# Altium schematic sheet Fluorimetro_Sheet.SchDoc(Fluorimetro_Sheet)
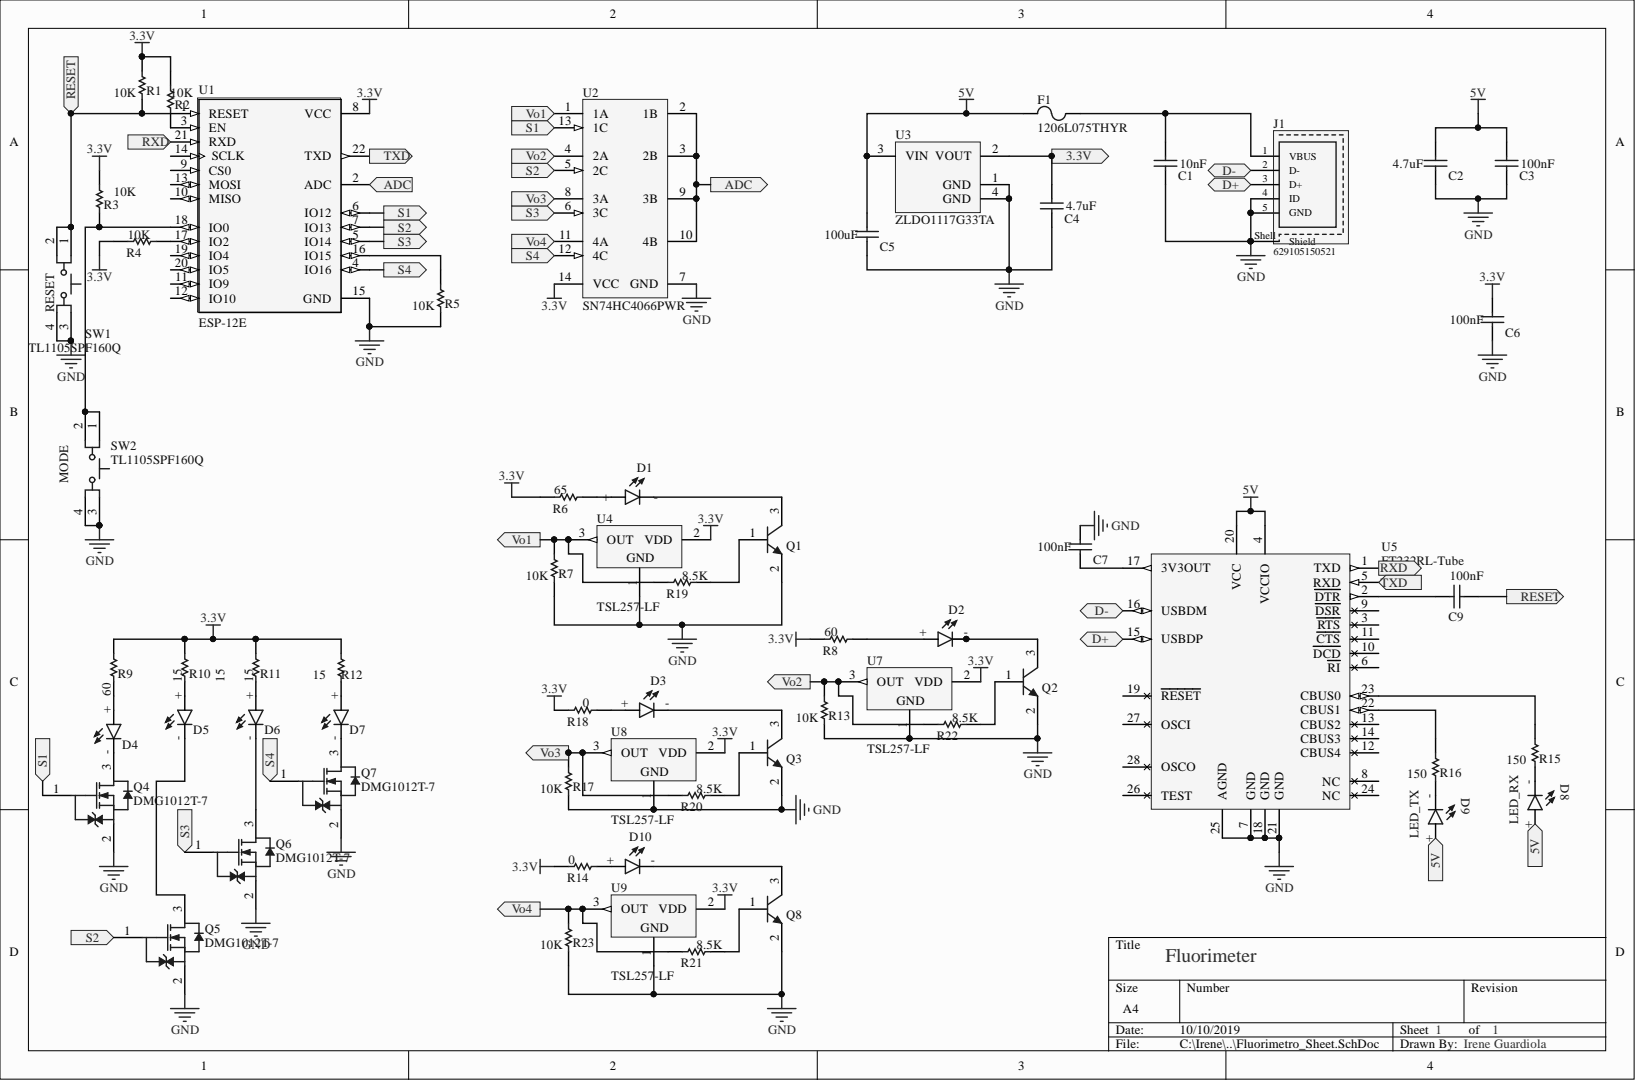
[diagram p0: Fluorimetro_Sheet.SchDoc]

## Parts
C1  CAP 0805  [CAP0805]  (value not drawn)
C2  CAP 0805  [CAP0805]  (value not drawn)
C3  GRM155R60J105KE19D  [CAP0603]  (value not drawn)
C4  CAP 0805  [CAP0805]  (value not drawn)
C5  GRM155R60J105KE19D  [CAP0603]  (value not drawn)
C6  GRM155R60J105KE19D  [CAP0603]  (value not drawn)
C7  GRM155R60J105KE19D  [CAP0603]  (value not drawn)
C9  GRM155R60J105KE19D  [CAP0603]  (value not drawn)
D1  LTST-C171KSKT  [DIOC200X125X110_LTST-C171KSKT(Primary) - duplicate - duplicate]  (value not drawn)
D2  LTST-C171GKT  [LTST-C171GKT]  (value not drawn)
D3  APT2012VBC/D  [19-21/BHC-AN1P2/3T]  (value not drawn)
D4  LTL-4273  [LED]  (value not drawn)
D5  LTL2R3TGY3KS  [LED]  (value not drawn)
D6  LTL2R3TBV3KS  [LED]  (value not drawn)
D7  VAOL-5GUV0T4  [LED]  (value not drawn)
D8  LTST-C171KRKT  [DIOC200X125X110_LTST-C171KRKT]  (value not drawn)
D9  LTST-C171KRKT  [DIOC200X125X110_LTST-C171KRKT]  (value not drawn)
D10  LTW-150TK  [LTW-150TK]  (value not drawn)
F1  1206L075THYR  [1206L075THYR]
J1  629105150521  [629105150521]
Q1  2SC5646A-TL-H  [2SC5646A-TL-H]  (value not drawn)
Q2  2SC5646A-TL-H  [2SC5646A-TL-H]  (value not drawn)
Q3  2SC5646A-TL-H  [2SC5646A-TL-H]  (value not drawn)
Q4  DMG1012T-7  [DIOD-SOT523-3_V]
Q5  DMG1012T-7  [DIOD-SOT523-3_V]
Q6  DMG1012T-7  [DIOD-SOT523-3_V]
Q7  DMG1012T-7  [DIOD-SOT523-3_V]
Q8  2SC5646A-TL-H  [2SC5646A-TL-H]  (value not drawn)
R1  RES0603  [RES0603]  (value not drawn)
R2  RES0603  [RES0603]  (value not drawn)
R3  RES0603  [RES0603]  (value not drawn)
R4  RES0603  [RES0603]  (value not drawn)
R5  RES0603  [RES0603]  (value not drawn)
R6  RES0603  [RES0603]  (value not drawn)
R7  RES0603  [RES0603]  (value not drawn)
R8  RES0603  [RES0603]  (value not drawn)
R9  RES0603  [RES0603]  (value not drawn)
R10  RES0603  [RES0603]  (value not drawn)
R11  RES0603  [RES0603]  (value not drawn)
R12  RES0603  [RES0603]  (value not drawn)
R13  RES0603  [RES0603]  (value not drawn)
R14  RES0603  [RES0603]  (value not drawn)
R15  RES0603  [RES0603]  (value not drawn)
R16  RES0603  [RES0603]  (value not drawn)
R17  RES0603  [RES0603]  (value not drawn)
R18  RES0603  [RES0603]  (value not drawn)
R19  RES0603  [RES0603]  (value not drawn)
R20  RES0603  [RES0603]  (value not drawn)
R21  RES0603  [RES0603]  (value not drawn)
R22  RES0603  [RES0603]  (value not drawn)
R23  RES0603  [RES0603]  (value not drawn)
SW1  TL1105SPF160Q  [PTS645SH432LFS]
SW2  TL1105SPF160Q  [PTS645SH432LFS]
U1  ESP-12E  [ESP8266-12E/ESP-12E]
U2  SN74HC4066PWR  [SN74HC4066PWR]
U3  ZLDO1117G33TA  [ZLDO1117G33TA]
U4  TSL257-LF  [TSL257-LF]
U5  FT232RL-Tube  [FT232RL]
U7  TSL257-LF  [TSL257-LF]
U8  TSL257-LF  [TSL257-LF]
U9  TSL257-LF  [TSL257-LF]

## Ports
3.3V, 5V, ADC, D+, D-, RESET, RXD, S1, S2, S3, S4, TXD, Vo1, Vo2, Vo3, Vo4

## Nets
3.3V: C6.2 R1.2 R2.2 R3.2 R4.2 R6.2 R8.2 R9.1 R10.1 R11.1 R12.1 R14.2 R18.2 U1.8(VCC) U2.14(VCC) U4.2 U7.2 U8.2 U9.2
5V: C2.2 C3.2 C5.2 F1.1 U3.3(VIN) U5.4(VCCIO) U5.20(VCC)
GND: C1.1 C2.1 C3.1 C4.1 C5.1 C6.1 C7.2 J1.4(ID) J1.5(GND) J1.Shell(Shield) Q1.2 Q2.2 Q3.2 Q4.2 Q5.2 Q6.2 Q7.2 Q8.2 R5.1 R7.1 R13.1 R17.1 R23.1 SW1.3 SW1.4(RESET) SW2.3 SW2.4 U1.15(GND) U2.7(GND) U3.1(GND) U3.4(GND) U4.1 U5.7(GND) U5.18(GND) U5.21(GND) U5.25(AGND) U7.1 U8.1 U9.1
ADC: U1.2(ADC) U2.2(1B) U2.3(2B) U2.9(3B) U2.10(4B) ; port ADC
RESET: C9.2 R1.1 SW1.1 SW1.2 U1.1(RESET) ; port RESET
RXD: U1.21(RXD) U5.1(TXD) ; port RXD
S1: Q4.1 U1.6(IO12) U2.13(1C) ; port S1
S2: Q5.1 U1.7(IO13) U2.5(2C) ; port S2
S3: Q6.1 U1.5(IO14) U2.6(3C) ; port S3
S4: Q7.1 U1.4(IO16) U2.12(4C) ; port S4
TXD: U1.22(TXD) U5.5(RXD) ; port TXD
Vo1: R7.2 R19.1 U2.1(1A) U4.3 ; port Vo1
Vo2: R13.2 R22.1 U2.4(2A) U7.3 ; port Vo2
Vo3: R17.2 R20.1 U2.8(3A) U8.3 ; port Vo3
Vo4: R21.1 R23.2 U2.11(4A) U9.3 ; port Vo4
NetC1_2: C1.2 F1.2 J1.1(VBUS)
NetC4_2: C4.2 U3.2(VOUT) ; port 3.3V
NetC7_1: C7.1 U5.17(3V3OUT)
NetC9_1: C9.1 U5.2(DTR)
NetD1_1: D1.1 Q1.3
NetD1_2: D1.2 R6.1
NetD2_+: D2.+ R8.1
NetD2_-: D2.- Q2.3
NetD3_+: D3.+ R18.1
NetD3_-: D3.- Q3.3
NetD4_+: D4.+ R9.2
NetD4_-: D4.- Q4.3
NetD5_+: D5.+ R10.2
NetD5_-: D5.- Q5.3
NetD6_+: D6.+ R11.2
NetD6_-: D6.- Q6.3
NetD7_+: D7.+ R12.2
NetD7_-: D7.- Q7.3
NetD8_+: D8.+ D9.+ ; port 5V
NetD8_-: D8.- R15.1
NetD9_-: D9.- R16.1
NetD10_+: D10.+ R14.1
NetD10_-: D10.- Q8.3
NetJ1_2: J1.2(D-) U5.16(USBDM)
NetJ1_3: J1.3(D+) U5.15(USBDP)
NetQ1_1: Q1.1 R19.2
NetQ2_1: Q2.1 R22.2
NetQ3_1: Q3.1 R20.2
NetQ8_1: Q8.1 R21.2
NetR2_1: R2.1 U1.3(EN)
NetR3_1: R3.1 SW2.1 SW2.2 U1.18(IO0)
NetR4_1: R4.1 U1.17(IO2)
NetR5_2: R5.2 U1.16(IO15)
NetR15_2: R15.2 U5.23(CBUS0)
NetR16_2: R16.2 U5.22(CBUS1)

Not connected: U1 9(CS0) 10(MISO) 11(IO9) 12(IO10) 13(MOSI) 14(SCLK) 19(IO4) 20(IO5); U5 3(RTS) 6(RI) 8(NC) 9(DSR) 10(DCD) 11(CTS) 12(CBUS4) 13(CBUS2) 14(CBUS3) 19(RESET) 24(NC) 26(TEST) 27(OSCI) 28(OSCO)

## Library data of the parts
C1 C2 C4 = CAP 0805: PartNumber: GRM155R60J105KE19D; Voltage Rating (DC): 3 V; Voltage Rating: 6.3 V; Type (Capacitors): X5R; Tolerance: 10%; Capacitance: 1 uF; Width: 0.5 mm; RoHSCompliant: true; REACH SVHC: No SVHC; Radiation Hardening: No; Pins: 2; Packaging: Tape and Reel; Package Quantity: 10000; PackageDescription: 2-Pin Surface Mount Device, Body 1 x 0.5 mm; Mounting Technology: Surface Mount; Mount: Surface Mount; Min Operating Temperature: -55 degC; Max Operating Temperature: 85 degC; Length: 1 mm; Height: 0.5 mm; DatasheetVersion: 08/2016; ComponentLink2URL: http://psearch.en.murata.com/capacitor/product/GRM155R60J105KE19%23.pdf; ComponentLink2Description: Datasheet; ComponentLink1URL: http://www.murata.com/; ComponentLink1Description: Manufacturer URL; Component Kind: Standard; Case Code (Metric): 1005; Case Code (Imperial): 0402; Case/Package: 0402; Library Name: Fluorimetro.SchLib
C3 C5-C7 C9 = CAP 0603: Voltage Rating (DC): 3 V; Voltage Rating: 6.3 V; Type (Capacitors): X5R; Tolerance: 10%; Capacitance: 1 uF; Width: 0.5 mm; RoHSCompliant: true; REACH SVHC: No SVHC; Radiation Hardening: No; Pins: 2; PartNumber: GRM155R60J105KE19D; Packaging: Tape and Reel; Package Quantity: 10000; PackageDescription: 2-Pin Surface Mount Device, Body 1 x 0.5 mm; Mounting Technology: Surface Mount; Mount: Surface Mount; Min Operating Temperature: -55 degC; Max Operating Temperature: 85 degC; Length: 1 mm; Height: 0.5 mm; DatasheetVersion: 08/2016; ComponentLink2URL: http://psearch.en.murata.com/capacitor/product/GRM155R60J105KE19%23.pdf; ComponentLink2Description: Datasheet; ComponentLink1URL: http://www.murata.com/; ComponentLink1Description: Manufacturer URL; Component Kind: Standard; Case Code (Metric): 1005; Case Code (Imperial): 0402; Case/Package: 0402; Library Name: Fluorimetro.SchLib
D1 = LTST-C171KSKT: Yellow 2 x 1.25 x 0.8 mm 130Â° Water Clear 50 mcd 2 V LED SMT; MF: Lite-On Inc.; Supplier Part Number 1: 859-LTST-C171KSKT; Supplier 1: Mouser; SnapEDA_Link: https://www.snapeda.com/parts/LTST-C171KSKT/Lite-On%20Inc./view-part/?ref=snap; Price: None; Package: 0805 Lite-On; MP: LTST-C171KSKT; Component Kind: Standard; Availability: Unavailable; Library Name: Fluorimetro.SchLib
D2 = LTST-C171GKT: LED estándar - SMD Green Clear 569nm; MP: LTST-C171KSKT; MF: Lite-On Inc.; Supplier Part Number 1: 859-LTST-C171GKT; Supplier 1: Mouser; SnapEDA_Link: https://www.snapeda.com/parts/LTST-C171KSKT/Lite-On%20Inc./view-part/?ref=snap; Price: None; Package: 0805 Lite-On; Component Kind: Standard; Availability: Unavailable; Library Name: Fluorimetro.SchLib
D3 = APT2012VBC/D: LED estándar - SMD 2.0X1.2MM BLUE SMD LED; MP: LTST-C171KSKT; MF: Lite-On Inc.; Supplier Part Number 1: 604-APT2012VBC/D; Supplier 1: Mouser; SnapEDA_Link: https://www.snapeda.com/parts/LTST-C171KSKT/Lite-On%20Inc./view-part/?ref=snap; Price: None; Package: 0805 Lite-On; Component Kind: Standard; Availability: Unavailable; Library Name: Fluorimetro.SchLib
D4 = LED 600nm: LED estándar - Agujero pasante Amber Diffused; Supplier Part Number 1: 859-LTL2R3TGY3KS; Supplier 1: Mouser; Component Kind: Standard; Library Name: Fluorimetro.SchLib
D5 = LED 525nm: LED estándar - Agujero pasante Green/ Water Clear 525-532nm7800mcd; Supplier Part Number 1: 859-LTL-4273; Supplier 1: Mouser; Component Kind: Standard; Library Name: Fluorimetro.SchLib
D6 = LED 470nm: LED estándar - Agujero pasante Blue/ Water Clear 465-472nm2500mcd; Supplier Part Number 1: 859-LTL2R3TBV3KS; Supplier 1: Mouser; Component Kind: Standard; Library Name: Fluorimetro.SchLib
D7 = LED 405nm: LED estándar - Agujero pasante Ultraviolet (UV) 405nm 160mcd 30deg; Supplier Part Number 1: 593-VAOL5GUV0T4; Supplier 1: Mouser; Component Kind: Standard; Library Name: Fluorimetro.SchLib
D8 D9 = LTST-C171KRKT: Light Emitting Diodes (LED) Red 630nm 54mcd@20mA Top View SMD,2x1.25x0.8mm; MP: LTST-C171KSKT; MF: Lite-On Inc.; Supplier Part Number 1: 859-LTST-C171KRKT; Supplier 1: Mouser; SnapEDA_Link: https://www.snapeda.com/parts/LTST-C171KSKT/Lite-On%20Inc./view-part/?ref=snap; Price: None; Package: 0805 Lite-On; Component Kind: Standard; Availability: Unavailable; Library Name: Fluorimetro.SchLib
D10 = LTW-150TK: LED estándar - SMD White 260MCD; MP: LTST-C171KSKT; MF: Lite-On Inc.; Supplier Part Number 1: 859-LTW-150TK; Supplier 1: Mouser; SnapEDA_Link: https://www.snapeda.com/parts/LTST-C171KSKT/Lite-On%20Inc./view-part/?ref=snap; Price: None; Package: 0805 Lite-On; Component Kind: Standard; Availability: Unavailable; Library Name: Fluorimetro.SchLib
F1 = 1206L075THYR: Fusibles de restablecimiento (PPTC) PTC 8V 1206 0.75A POLYFUSE SMD THIN; Manufacturer: Littelfuse; Working Voltage-Max: 30V; Trip Current: 0.29A; Hold Current [Ih]: 0.125A; Supplier Part Number 1: 576-1206L075THYR; Supplier 1: Mouser; Size: 1206; Package Reference: 1206; Package Description: 2-Pin Surface Mount Device, Body 3.2 x 1.65 mm; Operating Temp Range: -40to+85°C; Mounting Technology: SurfaceMount; Manufacturer URL: http://www.littelfuse.com/; Datasheet Version: 04/2012; Datasheet URL: https://datasheet.ciiva.com/26787/1206l012wr-26787215.pdf; Component Kind: Standard; Library Name: Fluorimetro.SchLib
J1 = CMP-1502-03252-1: Micro USB 2.0 Type B Receptacle WR-COM, Horizontal, SMT, with Pegs, High Current at Pin 1 & Pin 5; Manufacturer: Wurth Elektronik; Working Voltage: 30VAC; Rated Current: 3A; Contact Resistance: 30mOhm; Supplier Part Number 1: 710-629105150521; Supplier 1: Mouser; Part Number: 629105150521; Packaging: TapeandReel; Package Reference: 629105150521; Orientation: Horizontal; Operating Temperature: -40to85degC; Mounting Technology: SMT; Manufacturer URL: http://www.we-online.de; Gender: Receptacle; Datasheet URL: https://katalog.we-online.de/em/datasheet/629105150521.pdf; Connector Type: MicroUSB2.0TypeB; Component Kind: Standard; Publisher: WurthElektronik; Library Name: Fluorimetro.SchLib
Q1-Q3 Q8 = CMP-1046-00051-1: RF Transistor, 4 V, 30 mA, fT=12.5 GHz, NPN Single, 3-Pin SSFP, Pb-Free, Tape and Reel; Manufacturer: On Semiconductor; IC Continuous (A): 0.03; hFE Max: 160; fT Min (MHz): 8000; Supplier Part Number 1: 863-2SC5646A-TL-H; Supplier 1: Mouser; RoHS: TRUE; Polarity: NPN; PartNumber: 2SC5646A-TL-H; Packing: Tape and Reel; PackageReference: SSFP-3; PackageDescription: 3-Pin Small Outline Transistor, Body 1.4 x 0.8 mm, Pitch 0.45 mm; Mounting Technology: Surface Mount; ComponentLink3URL: http://www.onsemi.com/pub_link/Collateral/55GN01FA.PDF; ComponentLink3Description: Package Specification; ComponentLink2URL: http://www.onsemi.com/pub_link/Collateral/ENA1120-D.PDF; ComponentLink2Description: Datasheet; ComponentLink1URL: http://www.onsemi.com/; ComponentLink1Description: Manufacturer URL; Component Kind: Standard; Library Name: Fluorimetro.SchLib
Q4-Q7 = CMP-2000-07450-1: N-Channel Enhancement Mode MOSFET, 20 V, 630 mA, -55 to 150 degC, 3-Pin SOT23, RoHS, Tape and Reel; Manufacturer: Diodes Inc; Turn-On Delay Time: 5.1ns; Turn-Off Delay Time: 26.7ns; Threshold Voltage: 1V; Rise Time: 7.4ns; Rds On Max: 400mOhm; Power Dissipation: 280mW; Number of Elements: 1; Input Capacitance: 60.67pF; Gate to Source Voltage (Vgs): 6V; Fall Time: 12.3ns; Element Configuration: Single; Drain to Source Voltage (Vdss): 20V; Drain to Source Resistance: 300mOhm; Continuous Drain Current (ID): 630mA; Case\/Package: SOT-523; Width: 0.85mm; Weight: 7.1E-05oz; Supplier Part Number 1: 621-DMG1012T-7; Supplier 1: Mouser; RoHSCompliant: true; REACH SVHC: NoSVHC; Radiation Hardening: No; Packaging: TapeandReel; Package Reference: SOT523-3; Package Quantity: 3000; Package Description: 3-Pin Small Outline Transistor, Body 1.6 x 0.8 mm, Pitch 0.5 mm; On-State Resistance: 400MOhm; Number of Pins: 3; Number of Channels: 1; Mounting Technology: SurfaceMount; Mount: SurfaceMount; Min Operating Temperature: -55degC; Max Power Dissipation: 280mW; Max Operating Temperature: 150degC; Manufacturer URL: http://www.diodes.com/; Length: 1.7mm; Lead Free: LeadFree; Height: 0.8mm; Drain to Source Breakdown Voltage: 20V; Datasheet Version: Rev. 3-2, 01/2012; Datasheet URL: https://www.diodes.com/assets/Datasheets/ds31783.pdf; Contact Plating: Matte; Component Kind: Standard; Library Name: Fluorimetro.SchLib
R1-R23 = RES0603: Part Number: RC0402JR-070RL; Manufacturer: Yageo / Phycomp; Component Kind: Standard; Library Name: Fluorimetro.SchLib
SW1 SW2 = Pulsador: Tact Switch, SPST-NO, 50 mA, 12 VDC, -20 to 70 degC, 4-Pin THD, RoHS, Bulk; Manufacturer: E-Switch; Actuator Style: RoundButton; Actuation Force: 1.6N; Termination Style: RightAngle; Supplier Part Number 1: 611-PTS645SH432; Supplier 1: Mouser; Size: 6x6mm; Package URL: http://spec_sheets.e-switch.com/specs/P010201.pdf; Package Reference: TL1105SPF; Package Description: 4-Pin Through Hole Device, Body 6 x 6 mm; Mounting Technology: ThroughHole; Manufacturer URL: http://www.e-switch.ch/en/; Datasheet Version: 12/2013; Datasheet URL: https://www.e-switch.com/system/asset/product_line/data_sheet/140/TL1105.pdf; Contact Material: Silver; Component Kind: Standard; Library Name: Fluorimetro.SchLib
U1 = ESP8266-12E/ESP-12E: This WiFi module has a 32-bit MCU micro and clock speeds supporting 80 MHz or 160 MHz. Supports the RTOS and integrated Wi-Fi MAC/BB/RF/PA/LNA, and has an on-board antenna.; MF: AI-Thinker; Supplier Part Number 1: 485-2491; Supplier 1: Mouser; SnapEDA_Link: https://www.snapeda.com/parts/ESP8266-12E/ESP-12E/AI-Thinker/view-part/?ref=snap; Price: None; Package: None; MP: ESP8266-12E/ESP-12E; Component Kind: Standard; Availability: Unavailable; Library Name: Fluorimetro.SchLib
U2 = CMP-0782-00024-3: Quadruple Bilateral Analog Switches, PW0014A, LARGE T&R; Manufacturer: Texas Instruments; VCC(Min)(V): 2; VCC(Max)(V): 5.5; Ron(Typ)(Ohms): 50; Ron(Max)(Ohms): 85; Rating: Catalog; Output Type: CMOS; Crosstalk: Yes; Configuration: 4 X SPST (NO); Bandwidth(Max)(MHz): 30; Supplier Part Number 1: 595-SN74HC4066PWR; Supplier 1: Mouser; PackageVersion: http://www.ti.com/lit/ml/mpds360/mpds360.pdf; PackageReference: PW0014A; Operating Temperature Range(C): -40 to 85; Generic Part Number: SN74HC4066; DatasheetVersion: SCLS325G; Component Kind: Standard; Code_JEDEC: MO-153; Library Name: Fluorimetro.SchLib
U3 = ZLDO1117G33TA: Reguladores de voltaje LDO LDO Regulator IC 3.3V/1A; Supplier Part Number 1: 522-ZLDO1117G33TA; Supplier 1: Mouser; PackageVersion: revBB, Aug-2009; PackageReference: SOT-223-DB3; PackageDescription: 3-Lead Plastic Small Outline Transistor (DB) [SOT-223]; DatasheetVersion: revC, Oct-2006; Component Kind: Standard; Library Name: Fluorimetro.SchLib
U4 U7-U9 = CMP-0550-00087-2: Light-to-Voltage Converter - High Sensitivity, S-3, Pb-Free; Manufacturer: AMS; Responsivity Wavelength (nm): 640; Operating Voltage (V): 2.7-5.5; Supplier Part Number 1: 856-TSL257-LF; Supplier 1: Mouser; PartNumber: TSL257-LF; PackageVersion: TAOS052J, 09/2008; PackageReference: S-3; PackageDescription: 3-Pin Plastic Single In Line Side Looker Package, 4.6 x 2.6 mm Body, 2 mm Pitch; Mounting Technology: Through Hole; DatasheetVersion: TAOS023E; ComponentLink2URL: http://www.ams.com/eng/content/download/250427/976469/142383; ComponentLink2Description: Datasheet; ComponentLink1URL: www.ams.com; ComponentLink1Description: Manufacturer URL; Component Kind: Standard; Library Name: Fluorimetro.SchLib
U5 = CMP-0078-00028-3: USB UART Asynchronous Serial Data Transfer Chip, 28-pin SSOP, Tube; Manufacturer: FTDI; Supplier Part Number 1: 895-FT232RL-TUBE; Supplier 1: Mouser; RevisionNote: DatasheetVersion updated to 2.10 Mar-2012; PartNumber: FT232RL-Tube; PackageVersion: Mar-12; PackageReference: SSOP-28; PackageDescription: 28-Pin Small Outline Package 0.65 mm Pitch; DatasheetVersion: ver 2.10, Mar-2012; ComponentLink2URL: http://www.ftdichip.com/Support/Documents/DataSheets/ICs/DS_FT232R.pdf; ComponentLink2Description: Datasheet; ComponentLink1URL: http://www.ftdichip.com/; ComponentLink1Description: Manufacturer URL; Component Kind: Standard; Library Name: Fluorimetro.SchLib
